# Altium schematic sheet 05_Connectors_Debug.SchDoc
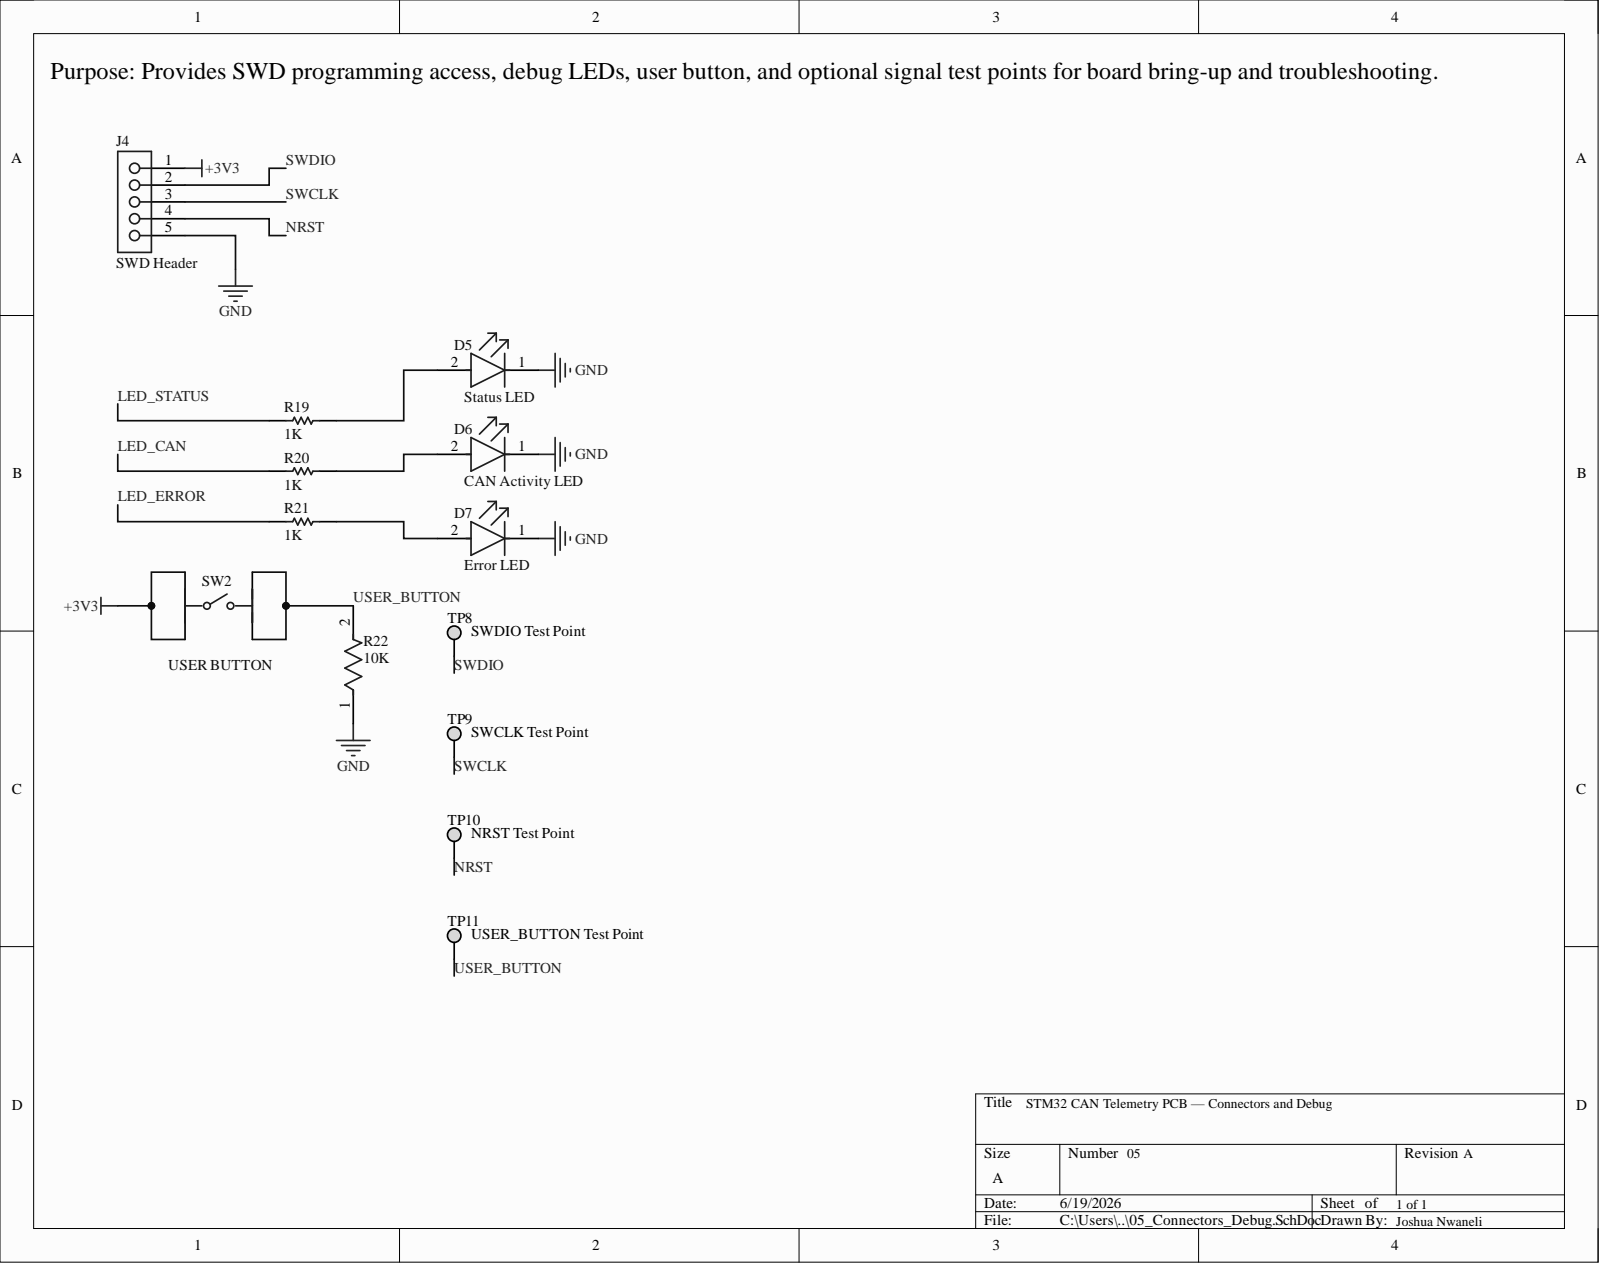
[diagram p0: 05_Connectors_Debug.SchDoc]

## Parts
D5  Status LED  [FP-SML-LXT0805IW-TR-MFG]
D6  CAN Activity LED  [FP-SML-LXT0805IW-TR-MFG]
D7  Error LED  [FP-SML-LXT0805IW-TR-MFG]
J4  SWD Header  [SMTC-SSW-105-01-X-S]
R19  1K  [RESC2013X50X30ML20T20]
R20  1K  [RESC2013X50X30ML20T20]
R21  1K  [RESC2013X50X30ML20T20]
R22  10K  [FP-CRGP0805-IPC_C]
SW2  USER BUTTON  [FP-7914J-1-032-MFG]
TP8  SWDIO Test Point  [KSTN-5014_V]
TP9  SWCLK Test Point  [KSTN-5014_V]
TP10  NRST Test Point  [KSTN-5014_V]
TP11  USER_BUTTON Test Point  [KSTN-5014_V]

## Nets
+3V3: J4.1 SW2.1_1- SW2.2_1-
GND: D5.1 D6.1 D7.1 J4.5 R22.1
LED_CAN: R20.1
LED_ERROR: R21.1
LED_STATUS: R19.1
NRST: J4.4 TP10.1
SWCLK: J4.3 TP9.1
SWDIO: J4.2 TP8.1
USER_BUTTON: R22.2 SW2.1_2+ SW2.2_2+ TP11.1
NetD5_2: D5.2 R19.2
NetD6_2: D6.2 R20.2
NetD7_2: D7.2 R21.2

## Library data of the parts
D5-D7 = CMP-1724-00002-2: LED RED DIFFUSED SMD; Approvals: UL; Dominant Wavelength: 635nm; Forward Current: 20mA; Forward Voltage: 2V; Lens Color: White; Lens Style: Rectangular; Luminous Intensity: 11mcd; Number of LEDs: 1; Viewing Angle: 140°; Case Code (Imperial): 0805; Case Code (Metric): 2012; Case/Package: 0805; Color: Red; Component Kind: Standard; Height: 0.8mm; Illumination Color: Red; Lead Free: Lead Free; Length: 2mm; Lens Transparency: Diffused; Library Name: Altium Content Vault; Max Operating Temperature: 80°C; Min Operating Temperature: -25°C; Mount: Surface Mount; Number of Pins: 2; Package Quantity: 1; Packaging: Tape and Reel; REACH SVHC: No SVHC; Test Current: 20mA; Wavelength: 635nm; Width: 1.25mm
J4 = CMP-1023-00345-1: SQ Post Socket, Through-hole, Vertical, -55 to 105 degC, 2.54mm Pitch, 5-Pin, Female, RoHS; Manufacturer: Samtec; PartNumber: SSW-105-01-T-S; Component Kind: Standard; ComponentLink1Description: Manufacturer URL; ComponentLink1URL: http://www.samtec.com; ComponentLink2Description: Datasheet; ComponentLink2URL: http://www.samtec.com/documents/webfiles/pdf/SSW_TH.PDF; ComponentLink3Description: Package Specification; ComponentLink3URL: http://www.samtec.com/documents/webfiles/cpdf/SSW-1XX-XX-XXX-X-XX-XXX-XX-MKT.pdf; DatasheetVersion: F-214; Library Name: Altium Content Vault; Mounting Technology: Through-hole; Orientation: Vertical; PackageDescription: Assembly, Socket Strip .016 X .031 Tail; PackageReference: SSW-105-01-X-S; PackageVersion: CA, 6/1987; Pitch: 2.54 mm; RoHS: True; Temperature Range: -55 to 105 degC
R19-R21 = CMP-2001-04268-1: Manufacturer: Vishay Dale; Part Number: CRCW08051K00FKTC; Component Kind: Standard; Library Name: Altium Content Vault
R22 = CMP-03211-006861-1: CRGP 0805 10K 1%; Manufacturer: TE; Manufacturer Part Number: CRGP0805F10K; Power Rating: 0.33W; Resistance: 10KΩ; Temperature Coefficient: ±100ppm/°C; Tolerance: 0.01; Value: 10KΩ; Voltage Rating: 150V; Ambient Temperature Range High: +70°C; Automotive: No; Category: Res; Component Kind: Standard; ComponentLink2Description: Datasheet; ComponentLink2URL: https://www.mouser.com/datasheet/2/418/NG_DS_9-1773463-9_A-1358566.pdf; Composition: Thick Film; Device Class L1: Passive Components; Device Class L2: Resistors; Device Class L3: Chip SMD Resistors; Digikey Description: CRGP 0805 10K 1%; Height: 0.65mm; Ipc Land Pattern Name: RESC200125X55; Lead Free: Yes; Library Name: Altium Content Vault; Octopart: https://octopart.com/crgp0805f10k-te+connectivity-91018782; Package: CRGP0805; RoHS: TRUE; Series: CRGP; Temperature: -55°C to +155°C; Temperature Range High: +155°C; Temperature Range Low: -55°C
SW2 = CMP-07427-000007-1: Normally open user pushbutton input; Actuator Material: Rubber; Actuator Type: Standard; Current Rating: 100mA; Illumination Type: Non-Illuminated; Mechanical Life: 100000Cycles; Operating Force: 300gf; Switch Function: Off-Mom; Throw Configuration: SPST; Voltage Rating (DC): 16V; Case/Package: SMD/SMT; Component Kind: Standard; Contact Material: Gold; Contact Plating: Silver; Depth: 5mm; Length: 5mm; Library Name: Altium Content Vault; Max Current Rating: 100mA; Max Operating Temperature: 125°C; Min Operating Temperature: -55°C; Mount: Surface Mount; Orientation: Straight; Package Quantity: 50; Packaging: Rail/Tube; RoHS Compliant: Yes; Series: 7914; Termination: J-Hook
TP8-TP11 = CMP-1672-00006-4: Test Point, Yellow, Through Hole, RoHS, Bulk; Manufacturer: Keystone; Colour: Yellow; Component Kind: Standard; ComponentLink1Description: Manufacturer URL; ComponentLink1URL: http://www.keyelco.com/; ComponentLink2Description: Datasheet; ComponentLink2URL: http://www.keyelco.com/product-pdf.cfm?p=1323; Contact Material: Bronze; Contact Plating: Silver; DatasheetVersion: Rev. F, 12/2013; Height: 8.12 mm; Library Name: Altium Content Vault; Mount: Through Hole; Mounting Technology: Through Hole; Package Quantity: 100; PackageDescription: 1-Pin Through Hole Device, Dia 3.17 mm; PackageReference: 5014; Packaging: Bulk; REACH SVHC: No SVHC; RoHSCompliant: true
